# Altium schematic sheet alarm_final.SchDoc
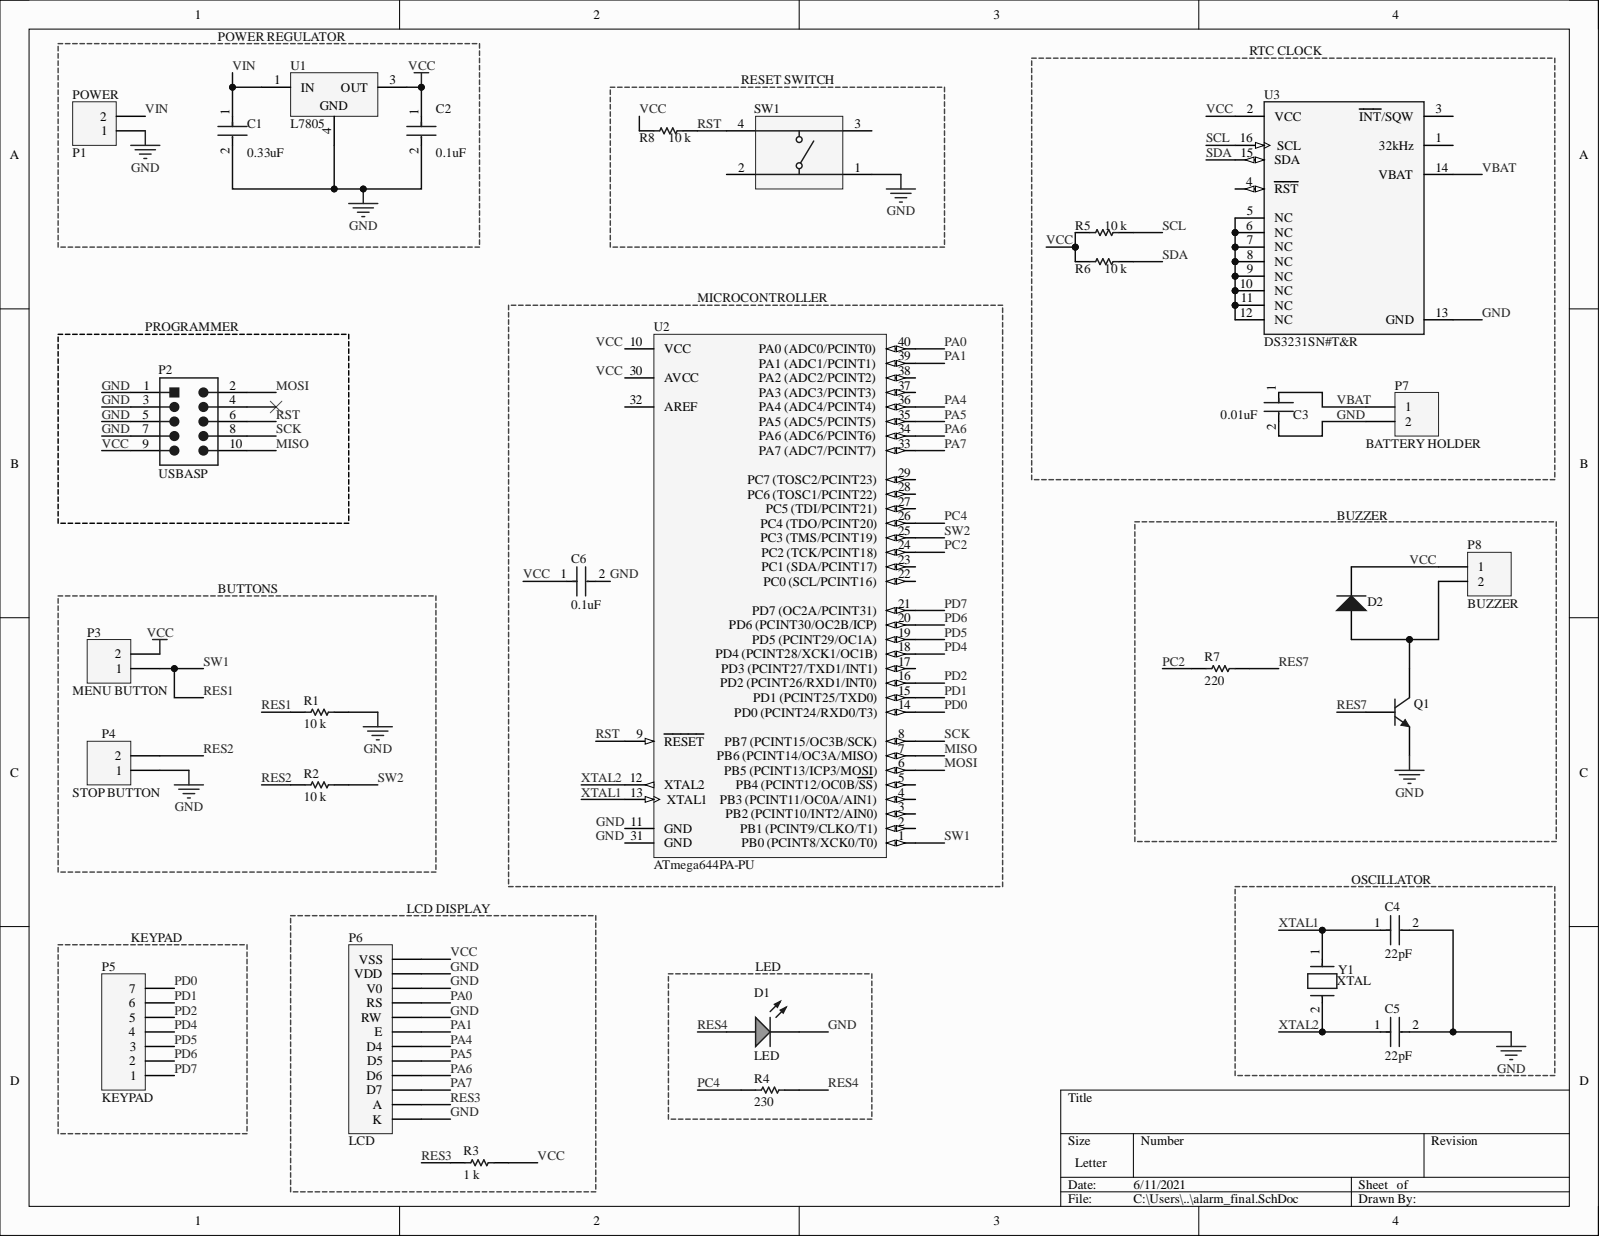
[diagram p0: alarm_final.SchDoc]

## Parts
C1  0.33uF  [FP-C1206C-EB-MFG]
C2  0.1uF  [FP-C1206C-EB-MFG]
C3  0.01uF  [FP-C1206C-EB-MFG]
C4  22pF  [FP-C1206C-EB-MFG]
C5  22pF  [FP-C1206C-EB-MFG]
C6  0.1uF  [FP-C1206C-EB-MFG]
D1  LED  [DIAL-597-3311-407F_V]
D2  Diode 1N4001  [DO-41]  (value not drawn)
P2  USBASP  [TECO-1-1634688-0-10_V]
P3  MENU BUTTON  [HDR1X2]
P4  STOP BUTTON  [HDR1X2]
P5  KEYPAD  [HDR1X7]
P6  LCD  [HDR1X12]
P7  BATTERY HOLDER  [HDR1X2]
P8  BUZZER  [HDR1X2]
POWER  P1  [HDR1X2]
Q1  2N3904  [TO-92A]  (value not drawn)
R1  10 k  [RESC3216X70X50NL05T20]
R2  10 k  [RESC3216X70X50NL05T20]
R3  1 k  [RESC3216X70X50NL05T20]
R4  230  [RESC3216X70X50NL05T20]
R5  10 k  [RESC3216X70X50NL05T20]
R6  10 k  [RESC3216X70X50NL05T20]
R7  220  [RESC3216X70X50NL05T20]
R8  10 k  [RESC3216X70X50NL05T20]
SW1  1825910-6  [TECO-1825910-6_V]  (value not drawn)
U1  L7805  [TO-263_N]
U2  ATmega644PA-PU  [40P6]
U3  DS3231SN#T&R  [FP-W16-H2-MFG]
Y1  XTAL  [FP-ABLS-MFG]

## Nets
GND: C1.2 C2.2 C3.2 C4.2 C5.2 C6.2 D1.2 P2.1 P2.3 P2.5 P2.7 P4.1 P6.1(K) P6.8(RW) P6.10(V0) P6.11(VDD) P7.2 POWER.1 Q1.1 R1.2 SW1.1 U1.4 U3.13(GND)
MISO: P2.10 U2.7(PB6_(PCINT14/OC3A/MISO))
MOSI: P2.2 U2.6(PB5_(PCINT13/ICP3/MOSI))
PA0: P6.9(RS) U2.40
PA1: P6.7(E) U2.39(PA1_(ADC1/PCINT1))
PA4: P6.6(D4) U2.36
PA5: P6.5(D5) U2.35(PA5_(ADC5/PCINT5))
PA6: P6.4(D6) U2.34(PA6_(ADC6/PCINT6))
PA7: P6.3(D7) U2.33(PA7_(ADC7/PCINT7))
PC2: R7.1 U2.24(PC2_(TCK/PCINT18))
PC4: R4.1 U2.26(PC4_(TDO/PCINT20))
PD0: P5.7 U2.14(PD0_(PCINT24/RXD0/T3))
PD1: P5.6 U2.15(PD1_(PCINT25/TXD0))
PD2: P5.5 U2.16(PD2_(PCINT26/RXD1/INT0))
PD4: P5.4 U2.18(PD4_(PCINT28/XCK1/OC1B))
PD5: P5.3 U2.19(PD5_(PCINT29/OC1A))
PD6: P5.2 U2.20(PD6_(PCINT30/OC2B/ICP))
PD7: P5.1 U2.21(PD7_(OC2A/PCINT31))
RES1: P3.1 R1.1 U2.1
RES2: P4.2 R2.1
RES3: P6.2(A) R3.1
RES4: D1.1 R4.2
RES7: Q1.2 R7.2
RST: P2.6 R8.2 SW1.4 U2.9(RESET)
SCK: P2.8 U2.8
SCL: R5.2 U3.16(SCL)
SDA: R6.2 U3.15(SDA)
SW2: R2.2 U2.25(PC3_(TMS/PCINT19))
VBAT: C3.1 P7.1 U3.14(VBAT)
VCC: C2.1 C6.1 D2.2 P2.9 P3.2 P6.12(VSS) P8.1 R3.2 R5.1 R6.1 R8.1 U1.3 U3.2(VCC)
VIN: C1.1 POWER.2 U1.1
XTAL1: C4.1 U2.13(XTAL1) Y1.1
XTAL2: C5.1 U2.12(XTAL2) Y1.2
NetD2_1: D2.1 P8.2 Q1.3
NetU3_5: U3.5(NC) U3.6(NC) U3.7(NC) U3.8(NC) U3.9(NC) U3.10(NC) U3.11(NC) U3.12(NC)

Not connected: P2 4; SW1 2 3; U2 2 3(PB2_(PCINT10/INT2/AIN0)) 4 5 10(VCC) 11(GND) 17(PD3_(PCINT27/TXD1/INT1)) 22(PC0_(SCL/PCINT16)) 23(PC1_(SDA/PCINT17)) 27(PC5_(TDI/PCINT21)) 28(PC6_(TOSC1/PCINT22)) 29(PC7_(TOSC2/PCINT23)) 30(AVCC) 31(GND) 32(AREF) 37(PA3_(ADC3/PCINT3)) 38; U3 1(32kHz) 3(INT/SQW) 4(RST)

## Library data of the parts
C1-C6 = CMP-03020-000153-1: CAP CER 0.1UF 100V X7R 1206; Manufacturer: KEMET; Manufacturer Part Number: C1206C104K1RACTU; Automotive Grade: Grade 0; Capacitance: 0.10 μF; Material: Ceramic; Temperature Coefficient: 15%; Tolerance: 0.1; Value: 0.10 μF; Automotive: Yes; Category: Cap; Component Kind: Standard; ComponentLink2Description: Datasheet; ComponentLink2URL: https://content.kemet.com/datasheets/KEM_C1007_X8R_ULTRA_150C_SMD.pdf; Device Class L1: Passive Components; Device Class L2: Capacitors; Device Class L3: Ceramic Capacitors; Digikey Description: CAP CER 0.1UF 100V X7R 1206; Height: 0.88mm; Ipc Land Pattern Name: CAPC320160X78; Lead Free: Yes; Library Name: Altium Content Vault; Mouser Description: CAP CER 0.1UF 100V X7R 1206; Octopart: https://octopart.com/c1206c104k1ractu-kemet-89521; Package: C1206C-EB; RoHS: TRUE; Temperature: -55°C to +150°C; Temperature Characteristic: X7R; Temperature Range High: +150°C; Temperature Range Low: -55°C; Voltage Rating: 100 V
D1 = CMP-2000-05651-1: LED Green, 75 mW, 30 mA, -30 to 85 degC, 2-Pin SMD, RoHS, Tape and Reel; Manufacturer: Dialight; Chip Material: GaP; DELETED: Compliant; Emitting Color: YellowGreen; Forward Current: 30mA; Forward Voltage: 2.5V; High Brightness: No; Lens Type: NonDiffused; Lum Intensity: 7.5mcd; Main Category: ChipLED; Number of  Elements: 1; Peak Wavelength: 560nm; Power Dissipation: 75W; Product Depth (mm): 1.5mm; Product Length (mm): 3mm; Reverse Voltage: 4V; Shape Type: Rectangular; Test Current (It): 20mA; Type: Uni-Color; Viewing Angle: 70deg; Component Kind: Standard; Datasheet URL: https://datasheet.ciiva.com/15117/getdatasheetpartid-142484-15117764.pdf; Datasheet Version: 07/2002; Lens Dimensions: 2x1.5x0.9mm; Library Name: Altium Content Vault; Luminous Intensity: 7.5mcd; Manufacturer URL: http://www.dialight.com/; Mounting: SurfaceMount; Mounting Technology: SurfaceMount; Operating Temp Range: -30Cto85C; Operating Temperature Classification: Commercial; Package Description: 2-Pin Surface Mount Device, Body 1.5 x 3 mm; Package Reference: 597-3311-407F; Package Type: SMD; Packaging: TapeandReel; Pin Count: 2; Product Height (mm): 1.5mm; Rad Hardened: No
D2 = Diode 1N4001: 1 Amp General Purpose Rectifier; Code_JEDEC: DO-204-AL; Component Kind: Standard; ComponentLink1Description: Manufacturer Link; ComponentLink1URL: http://www.fairchildsemi.com/; ComponentLink2Description: Datasheet; ComponentLink2URL: http://www.fairchildsemi.com/ds/1N%2F1N4001.pdf; DatasheetVersion: 1998; LatestRevisionDate: 17-Jul-2002; LatestRevisionNote: Re-released for DXP Platform.; Library Name: Miscellaneous Devices.IntLib; PackageDescription: Diode, Axial; Body 5.2 x 2.7 mm (LxDia. max), Lead Dia. 0.86 mm (max); PackageReference: DO-41; PackageVersion: Aug-1999; Published: 8-Jun-2000; Signal Integrity: 1N4001; Simulation: 1N4001; Publisher: Altium Limited
P2 = CMP-2000-05854-1: Male Header, Pitch 2.54 mm, 2 x 5 Postion, Height 9.15 mm, Tail Length 3.2 mm, -40 to 105 degC, RoHS, Bulk; Manufacturer: TE Connectivity; PartNumber: 1-1634688-0; Contact Termination Type: ThroughHole; No. of Contacts: 10Contacts; No. of Positions: 10; No. of Rows: 2; Package Version: Rev. C2, 02/2011; Pitch: 2.54mm; SVHC: ToBeAdvised; Component Kind: Standard; Contact Material: Brass; Contact Plating: GoldPlatedContacts; Datasheet URL: https://datasheet.ciiva.com/6831/eng-cd-1634688-c2-6831201.pdf; Datasheet Version: Rev. C2, 02/2011; Gender: Header; Library Name: Altium Content Vault; Manufacturer URL: http://www.te.com/; Mounting Technology: ThroughHole; Orientation: Straight; Package Description: 10-Pin Connector, Body 20.35 x 8.7 mm, Pitch 2.54 mm; Package Reference: 1-1634688-0; Package URL: https://datasheet.ciiva.com/6831/eng-cd-1634688-c2-6831201.pdf; Pitch Spacing: 2.54mm; Product Range: -
P3 P4 P7 P8 POWER = Header 2: Header, 2-Pin; Component Kind: Standard; LatestRevisionDate: 17-Jul-2002; LatestRevisionNote: Re-released for DXP Platform.; Library Name: Miscellaneous Connectors.IntLib; Signal Integrity: Connector; Publisher: Altium Limited
P5 = Header 7: Header, 7-Pin; Component Kind: Standard; LatestRevisionDate: 17-Jul-2002; LatestRevisionNote: Re-released for DXP Platform.; Library Name: Miscellaneous Connectors.IntLib; Signal Integrity: Connector; Publisher: Altium Limited
P6 = Header 12: Header, 12-Pin; Component Kind: Standard; LatestRevisionDate: 17-Jul-2002; LatestRevisionNote: Re-released for DXP Platform.; Library Name: Miscellaneous Connectors.IntLib; Signal Integrity: Connector; Publisher: Altium Limited
Q1 = 2N3904: NPN General Purpose Amplifier; Component Kind: Standard; ComponentLink1Description: Manufacturer Link; ComponentLink1URL: http://www.fairchildsemi.com/; ComponentLink2Description: Datasheet; ComponentLink2URL: http://www.fairchildsemi.com/ds/2N%2F2N3904.pdf; DatasheetVersion: 1997; LatestRevisionDate: 15-Jan-2003; LatestRevisionNote: PCB Footprint 'BCY-W3/D4.7' replaced; Library Name: Miscellaneous Devices.IntLib; PackageDescription: TO, Flat Index; 3 In-Line, Axial Leads; Body Dia. 4.5mm; Leads 0.51 x 0.48 mm (max); PackageReference: TO-92A; PackageVersion: Sep-1998; Published: 8-Jun-2000; Signal Integrity: 2N3904; Simulation: 2N3904; Publisher: Altium Limited
R1-R8 = CMP-2003-00020-1: Manufacturer: Panasonic; Part Number: ERJ-8ENF1004V; Component Kind: Standard; Library Name: Altium Content Vault
SW1 = CMP-1684-00021-1: Tact Switch, SPST-NO, 0.05 A, -35 to 85 degC, 4-Pin THD, RoHS, Bulk; Manufacturer: TE Connectivity; Actuator Color: Black; Actuator Length: 1.4mm; Actuator Material: PolybutyleneTerephthalate; Actuator Style: RoundButton; Body Orientation: Straight; Bushing Type: Unthreaded; Contact Rating @ Voltage: 0.05A@24VDC; Dielectric Strength: 500VAC; Electrical Life: 100000Cycles; Function: MomentaryContact; Illumination: N/R; Maximum Contact Resistance: 100mOhm; Maximum DC Voltage Rating: 24V; Pole Throw Configuration: SPST; Switch Configuration: OFF(ON); Base Material: N/A; Component Kind: Standard; Contact Material: CopperAlloy; Contact Plating: Silver; Datasheet URL: http://www.te.com/commerce/DocumentDelivery/DDEController?Action=showdoc&DocId=Customer+Drawing%7F1825910%7FC9%7Fpdf%7FEnglish%7FENG_CD_1825910_C9.pdf%7F1825910-6; Datasheet Version: Rev. C9; Dimension: 5.99x5mm; Family: 1825910; Housing Material: N/A; Library Name: Altium Content Vault; Manufacturer URL: http://www.te.com; Maximum AC Voltage Rating: N/A; Mechanical Life: 100000Cycles; Minimum Insulation Resistance: 100MOhm; Mounting: ThroughHole; Operating Temperature: -35to85C; Package Description: 4-Pin Through Hole Device, Body 5.99 x 5.99 mm, Pitch 6.5 mm; Package Reference: THD; Packaging: N/A; Part No: 1825910-6; Product Weight: N/A; RoHS: Yes; Seal Description: N/A; Seal Location: N/A; Terminal Type: PCPins; Thread Size: N/R
U1 = CMP-0244-00214-1: Positive Voltage Regulator, 3-Pin D2PAK, Tape and Reel; Component Kind: Standard; ComponentLink1Description: Manufacturer URL; ComponentLink1URL: http://www.st.com; ComponentLink2Description: Datasheet; ComponentLink2URL: http://www.st.com/internet/com/TECHNICAL_RESOURCES/TECHNICAL_LITERATURE/DATASHEET/CD00000444.pdf; DatasheetVersion: 16/11/2011; Library Name: Altium Content Vault; PackageDescription: 3-Pin Transistor Outline Package 2.54 mm Pitch, 15.4 mm Lead Span (D2PAK); PackageReference: TO-263; PackageVersion: 2011
U2 = CMP-0095-00039-2: 8-bit AVR Microcontroller, 64KB Flash, 2KB EEPROM, 4KB SRAM, 40-pin PDIP, Industrial Grade (-40°C to 85°C); Code_JEDEC: MS-011-AC; Component Kind: Standard; ComponentLink1Description: Manufacturer URL; ComponentLink1URL: http://www.atmel.com/; ComponentLink2Description: ATmega164A/164PA/324A/324PA/644A/644PA/1284/1284P Datasheet; ComponentLink2URL: http://www.atmel.com/dyn/resources/prod_documents/doc8272.pdf; ComponentLink3Description: ATmega164A/164PA/324A/324PA/644A/644PA/1284/1284P Summary; ComponentLink3URL: http://www.atmel.com/dyn/resources/prod_documents/8272S.pdf; DatasheetVersion: revC, Jun-2011; Library Name: Altium Content Vault; PackageDescription: 40-lead (0.600"/15.24mm Wide) Plastic Dual Inline Package (PDIP); PackageReference: 40P6; PackageVersion: revB, Sep-2001; RevisionNote: DatasheetVersion & Sch pin names updated. URLs added.
U3 = CMP-0254-00375-2: IC RTC CLK/CALENDAR I2C 16-SOIC; Date Format: YY-MM-DD-dd; Logic Function: Clock; Max Supply Voltage: 5.5V; Min Supply Voltage: 2.3V; Operating Supply Voltage: 3.3V; Time Format: HH:MM:SS; Case/Package: SOIC; Component Kind: Standard; Contact Plating: Tin; Interface: I2C; Lead Free: Lead Free; Library Name: Altium Content Vault; Max Operating Temperature: 85°C; Min Operating Temperature: -40°C; Mount: Surface Mount; Number of Pins: 16; Package Quantity: 1000; Packaging: Tape and Reel; Radiation Hardening: No
Y1 = CMP-27762-000003-1: CRYSTAL 16.0000MHZ 18PF SMD; Manufacturer: Abracon; Manufacturer Part Number: ABLS-16.000MHZ-K4T; Esr: 40Ω; Frequency: 16MHz; Stability: 50ppm; Standoff Height: 0mm; Tolerance: 30ppm; Automotive: No; Category: Crys; Component Kind: Standard; ComponentLink2Description: Datasheet; ComponentLink2URL: https://abracon.com/Resonators/abls.pdf; Device Class L1: Passive Components; Device Class L2: Crystals / Oscillators; Device Class L3: Crystals; Digikey Description: CRYSTAL 16.0000MHZ 18PF SMD; Height: 4.3mm; Lead Free: Yes; Library Name: Altium Content Vault; Load Capacitance: 18pF; Mouser Description: Crystal 16MHz ±30ppm (Tol) ±50ppm (Stability) 18pF FUND 40Ohm 2-Pin HC-49/US SMD T/R; Octopart: https://octopart.com/abls-16.000mhz-k4t-abracon-18618626; Package: ABLS; RoHS: TRUE; Series: ABLS; Temperature: -40°C to +125°C; Temperature Range High: +125°C; Temperature Range Low: -40°C
